# Altium schematic sheet LSM_IR_00.SchDoc(LSM_IR_00)
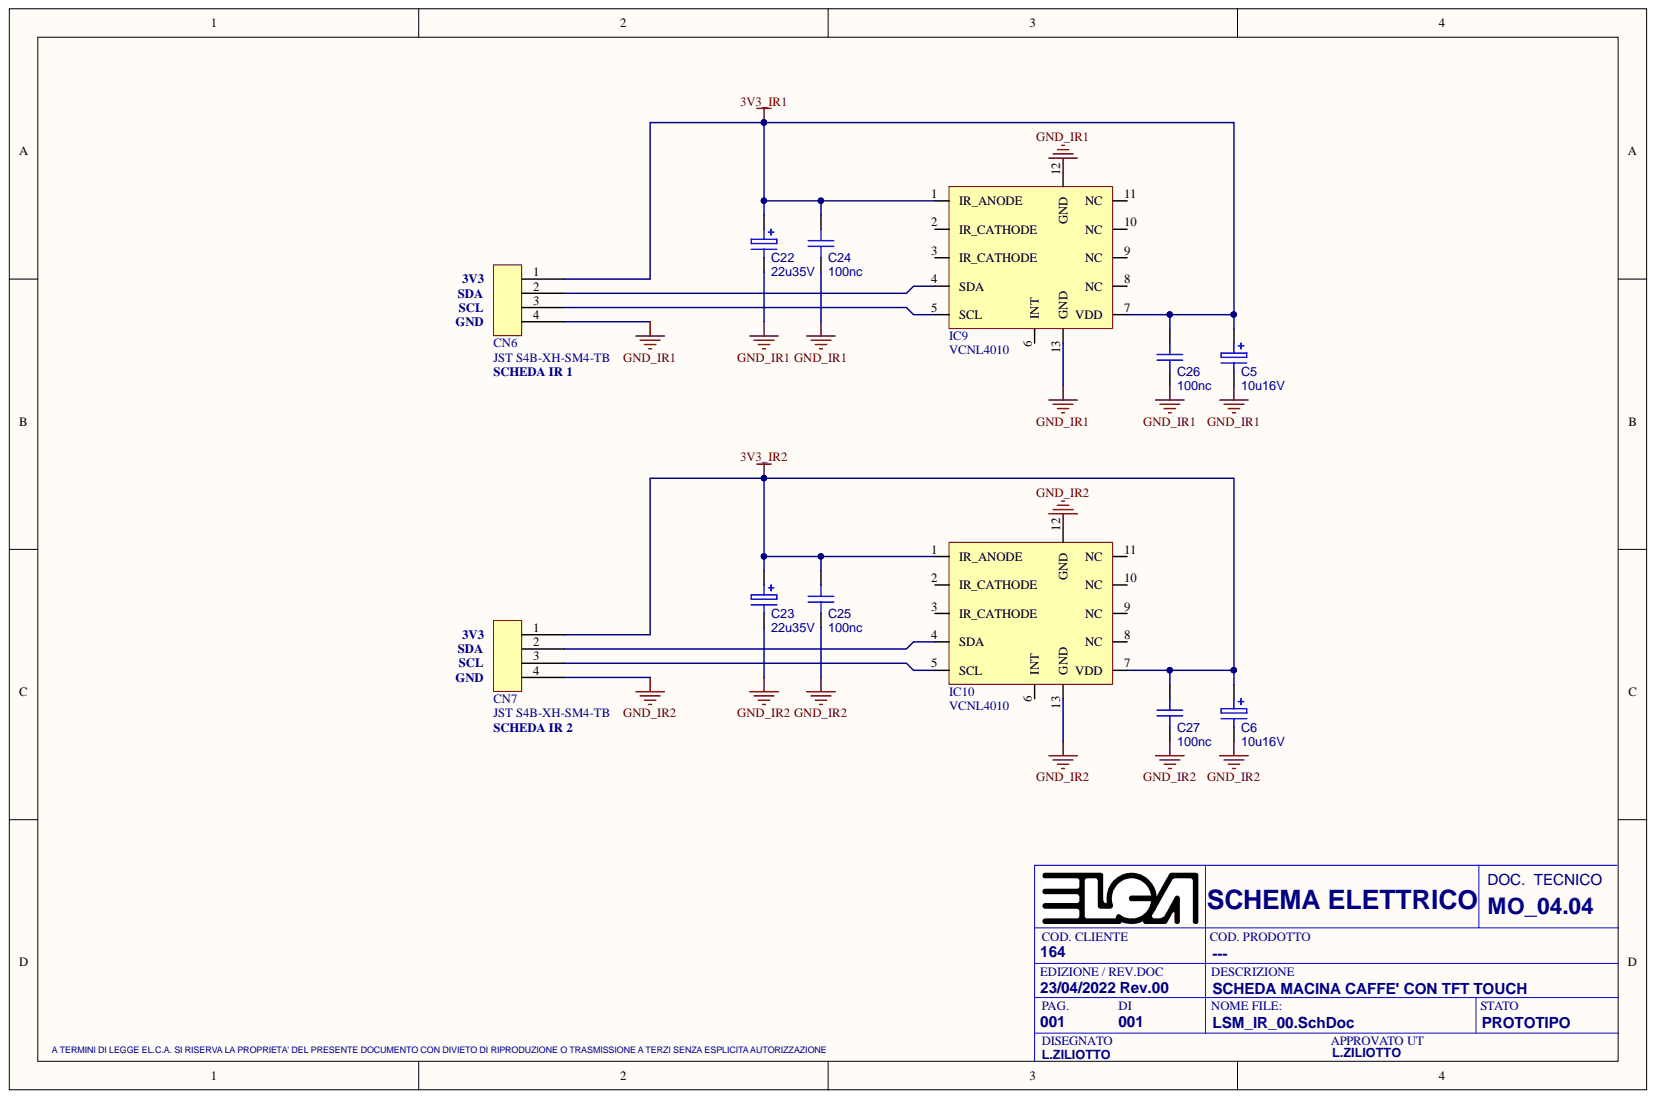
[diagram p0: LSM_IR_00.SchDoc]

## Parts
C5  10u16V  [CASEA]
C6  10u16V  [CASEA]
C22  22u35V  [CASEB]
C23  22u35V  [CASEB]
C24  100nc  [0603]
C25  100nc  [0603]
C26  100nc  [0603]
C27  100nc  [0603]
CN6  JST S4B-XH-SM4-TB  [JST S4B-XH-SM4-TB]
CN7  JST S4B-XH-SM4-TB  [JST S4B-XH-SM4-TB]
IC9  VCNL4010  [VCNL4010]
IC10  VCNL4010  [VCNL4010]

## Nets
3V3_IR1: C5.1 C22.1 C24.1 C26.1 CN6.1 IC9.1(IR_ANODE) IC9.7(VDD)
3V3_IR2: C6.1 C23.1 C25.1 C27.1 CN7.1 IC10.1(IR_ANODE) IC10.7(VDD)
GND_IR1: C5.2 C22.2 C24.2 C26.2 CN6.4 IC9.12(GND) IC9.13(GND)
GND_IR2: C6.2 C23.2 C25.2 C27.2 CN7.4 IC10.12(GND) IC10.13(GND)
NetCN6_2: CN6.2 IC9.4(SDA)
NetCN6_3: CN6.3 IC9.5(SCL)
NetCN7_2: CN7.2 IC10.4(SDA)
NetCN7_3: CN7.3 IC10.5(SCL)
N00005:

Not connected: IC9 2(IR_CATHODE) 3(IR_CATHODE) 6(INT) 8(NC) 9(NC) 10(NC) 11(NC); IC10 2(IR_CATHODE) 3(IR_CATHODE) 6(INT) 8(NC) 9(NC) 10(NC) 11(NC)

## Library data of the parts
C5 C6 C22 C23 = ELECTRO1: Part Field 1: ELET. 5X5,5 47MF 16V SMD; Part Field 2: ZCES5X5,547M16V; Component Kind: Standard
C24-C27 = CAP: Part Field 1: CER. 100nF 50V Y5V 0805; Part Field 2: ZCC0805100NSMD; Component Kind: Standard
CN6 CN7 = 4PIN: Component Kind: Standard; Library Name: SCHEMATICI.lib
IC9 IC10 = VCNL4010: Component Kind: Standard; Library Name: MICRO-INTEGRATI.Lib
